# Altium schematic sheet DC-DC Converter.SchDoc
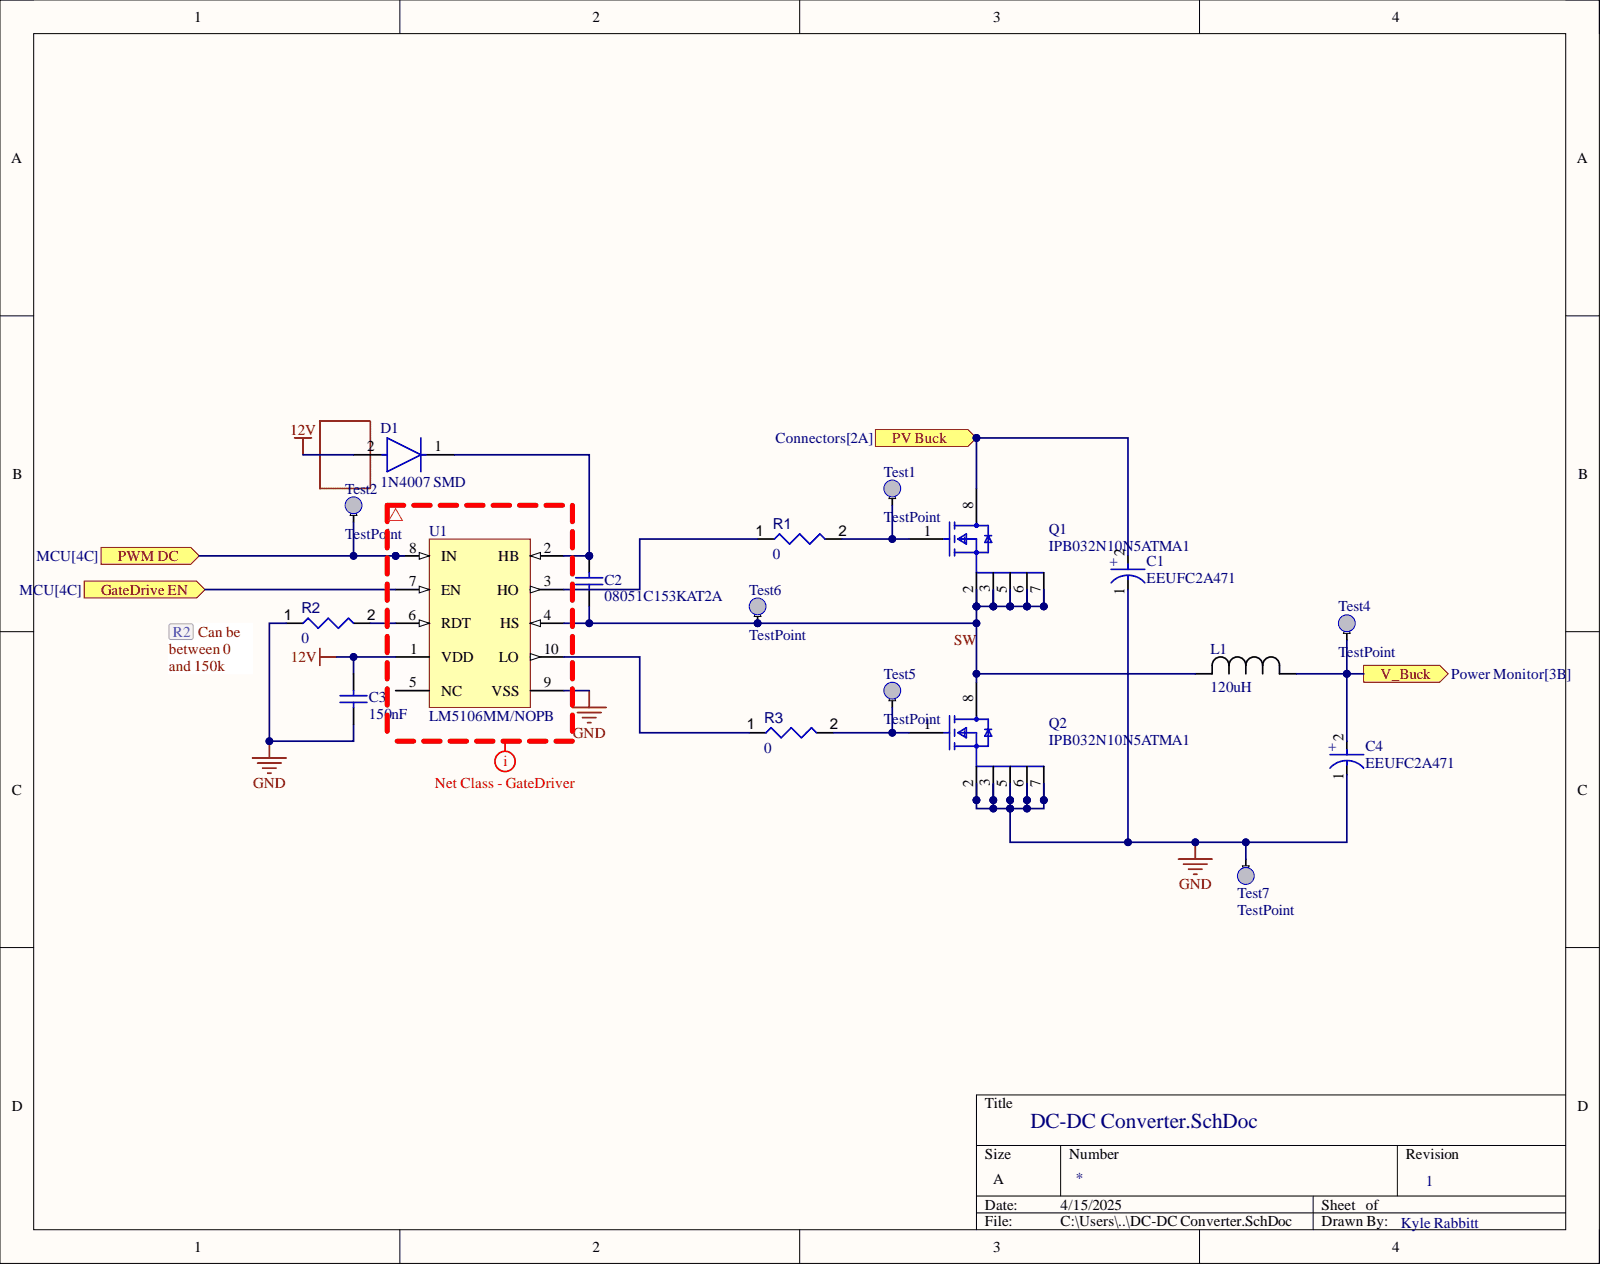
[diagram p0: DC-DC Converter.SchDoc]

## Parts
C1  EEUFC2A471  [FP-RAD-TH-D_16_0_5-L_40_2-MFG]
C2  08051C153KAT2A  [CAPC2013X94X50NL20T25]
C3  150nF  [CAPC2013X140X50LL20T25]
C4  EEUFC2A471  [FP-RAD-TH-D_16_0_5-L_40_2-MFG]
D1  1N4007 SMD  [FP-1N4007_SMD-IPC_C]
L1  120uH  [IND_2300HT-V_56_42]
Q1  IPB032N10N5ATMA1  [FP-PG-TO263-7-MFG]
Q2  IPB032N10N5ATMA1  [FP-PG-TO263-7-MFG]
R1  value 0  [RESC0603(1608)_L]  (hidden comment: 0R3J)
R2  value 0  [RESC0603(1608)_L]  (hidden comment: 0R3J)
R3  value 0  [RESC0603(1608)_L]  (hidden comment: 0R3J)
Test1  TestPoint  [Test_IN]  (no BOM)
Test2  TestPoint  [Test_IN]  (no BOM)
Test4  TestPoint  [Test_IN]  (no BOM)
Test5  TestPoint  [Test_IN]  (no BOM)
Test6  TestPoint  [Test_IN]  (no BOM)
Test7  TestPoint  [Test_IN]  (no BOM)
U1  LM5106MM/NOPB  [DGS0010A_N]

## Ports
GateDrive EN, PV Buck, PWM DC, V_Buck

## Nets
12V: C3.2 D1.2 U1.1(VDD)
GND: C1.1 C3.1 C4.1 Q2.2 Q2.3 Q2.5 Q2.6 Q2.7 R2.1 Test7.IN U1.9(VSS)
GateDrive EN: U1.7(EN) ; port GateDrive EN
PV Buck: C1.2 Q1.8 ; port PV Buck
PWM DC: Test2.IN U1.8(IN) ; port PWM DC
SW: C2.1 L1.2 Q1.2 Q1.3 Q1.5 Q1.6 Q1.7 Q2.8 Test6.IN U1.4(HS)
V_Buck: C4.2 L1.1 Test4.IN ; port V_Buck
NetC2_2: C2.2 D1.1 U1.2(HB)
NetQ1_1: Q1.1 R1.2 Test1.IN
NetQ2_1: Q2.1 R3.2 Test5.IN
NetR1_1: R1.1 U1.3(HO)
NetR2_2: R2.2 U1.6(RDT)
NetR3_1: R3.1 U1.10(LO)

Not connected: U1 5(NC)

## Library data of the parts
C1 C4 = CMP-05427-000270-1: Aluminum Electrolytic Capacitors (Radial Lead Type) AEC-Q200 Qualified 470uF ±20% 100V; Dielectric Material: Aluminium; ESR (Equivalent Series Resistance): 47mR; Lead Diameter: 0.8mm; Leakage Current: 3uA; Life (Hours): 5000Hour; Polarity: Polar; Ripple Current: 1.92A; Capacitance: 470uF; Case/Package: Radial; Component Kind: Standard; Construction: RADIAL; Contact Plating: Tin; Diameter: 16mm; Height: 40mm; Height - Seated (Max): 1.575inch; Impedance: 47mR; Lead Free: Lead Free; Lead Pitch: 7.5mm; Length: 40mm; Library Name: Altium Content Vault; Max Operating Temperature: 105°C; Min Operating Temperature: -55°C; Mount: Through Hole; Package Quantity: 100; Packaging: Bulk; Radiation Hardening: No; Ratings: AEC-Q200; REACH SVHC: No SVHC; RoHS Compliant: Yes; Series: FC; Termination: Radial; Tolerance: 20%; Voltage: 100V; Voltage Rating: 100V; Voltage Rating (DC): 100V
C2 = CMP-2007-04180-1: 15nF / 0.01uF; Manufacturer: AVX Interconnect / Elco; Component Kind: Standard; Library Name: Altium Content Vault; Part Number: 08051C153KAT2A
C3 = CMP-1036-04480-3: 08053C154KAT2A; Manufacturer: AVX Interconnect / Elco; Component Kind: Standard; Library Name: Altium Content Vault; Part Number: 08053C154KAT2A
D1 = CMP-12389-000001-1: Rectifier, 1Kv, 1A, Do-214Ac Rohs Compliant: Yes; Component Kind: Standard; Library Name: Altium Content Vault
L1 = 2300HT-121-V-RC: 120uH  2300HT-121-V-RC; Mfr_Name: Bourns Electronics; Component Kind: Standard; Library Name: 2300HT-121-V-RC.IntLib
Q1 Q2 = CMP-32901-001276-1: MOSFET N-CH 100V 166A TO263-7; Input Capacitance: 6.97nF; Max Power Dissipation: 187W; Rds On Max: 3.2mR; Case/Package: TO-263-7; Component Kind: Standard; Continuous Drain Current (ID): 166A; Drain to Source Voltage (Vdss): 100V; Halogen Free: Halogen Free; Lead Free: Contains Lead; Library Name: Altium Content Vault; Max Dual Supply Voltage: 100V; Max Operating Temperature: 175°C; Min Operating Temperature: -55°C; Mount: Surface Mount; Packaging: Tape and Reel; RoHS Compliant: Yes; Series: OptiMOS™
R1-R3 = CMP-009-00063-2: Jumper 0603 (1608 Metric); Case/Package: 0603; Component Kind: Standard; Mounting Technology: SMT; Pins: 2; Library Name: WPI High Power Rocketry Club
U1 = CMP-0063-01354-3: 100V Half-Bridge Gate Driver with Programmable Dead-Time, 10-pin MSOP, Pb-Free; Manufacturer: Texas Instruments; CompType: MiscPower; PackageTopMarking: ZXTT*5106; PowerWise: N; Code_JEDEC: MO-187-BA; Component Kind: Standard; ComponentLink1Description: Datasheet URL; ComponentLink1URL: http://www.ti.com/general/docs/lit/getliterature.tsp?genericPartNumber=LM5106&fileType=pdf; Library Name: Altium Content Vault; PackageDescription: Mini-SO, Molded, .118 x .118 x .034in Body, 10 Lead, .0197in Pitch; PackageReference: DGS0010A; PinCount: 10; RoHS: Y
Test1 Test2 Test4-Test7 = TestPoint: Library Name: Test_POINTS.IntLib
